# Altium schematic sheet Herba-Automata.SchDoc
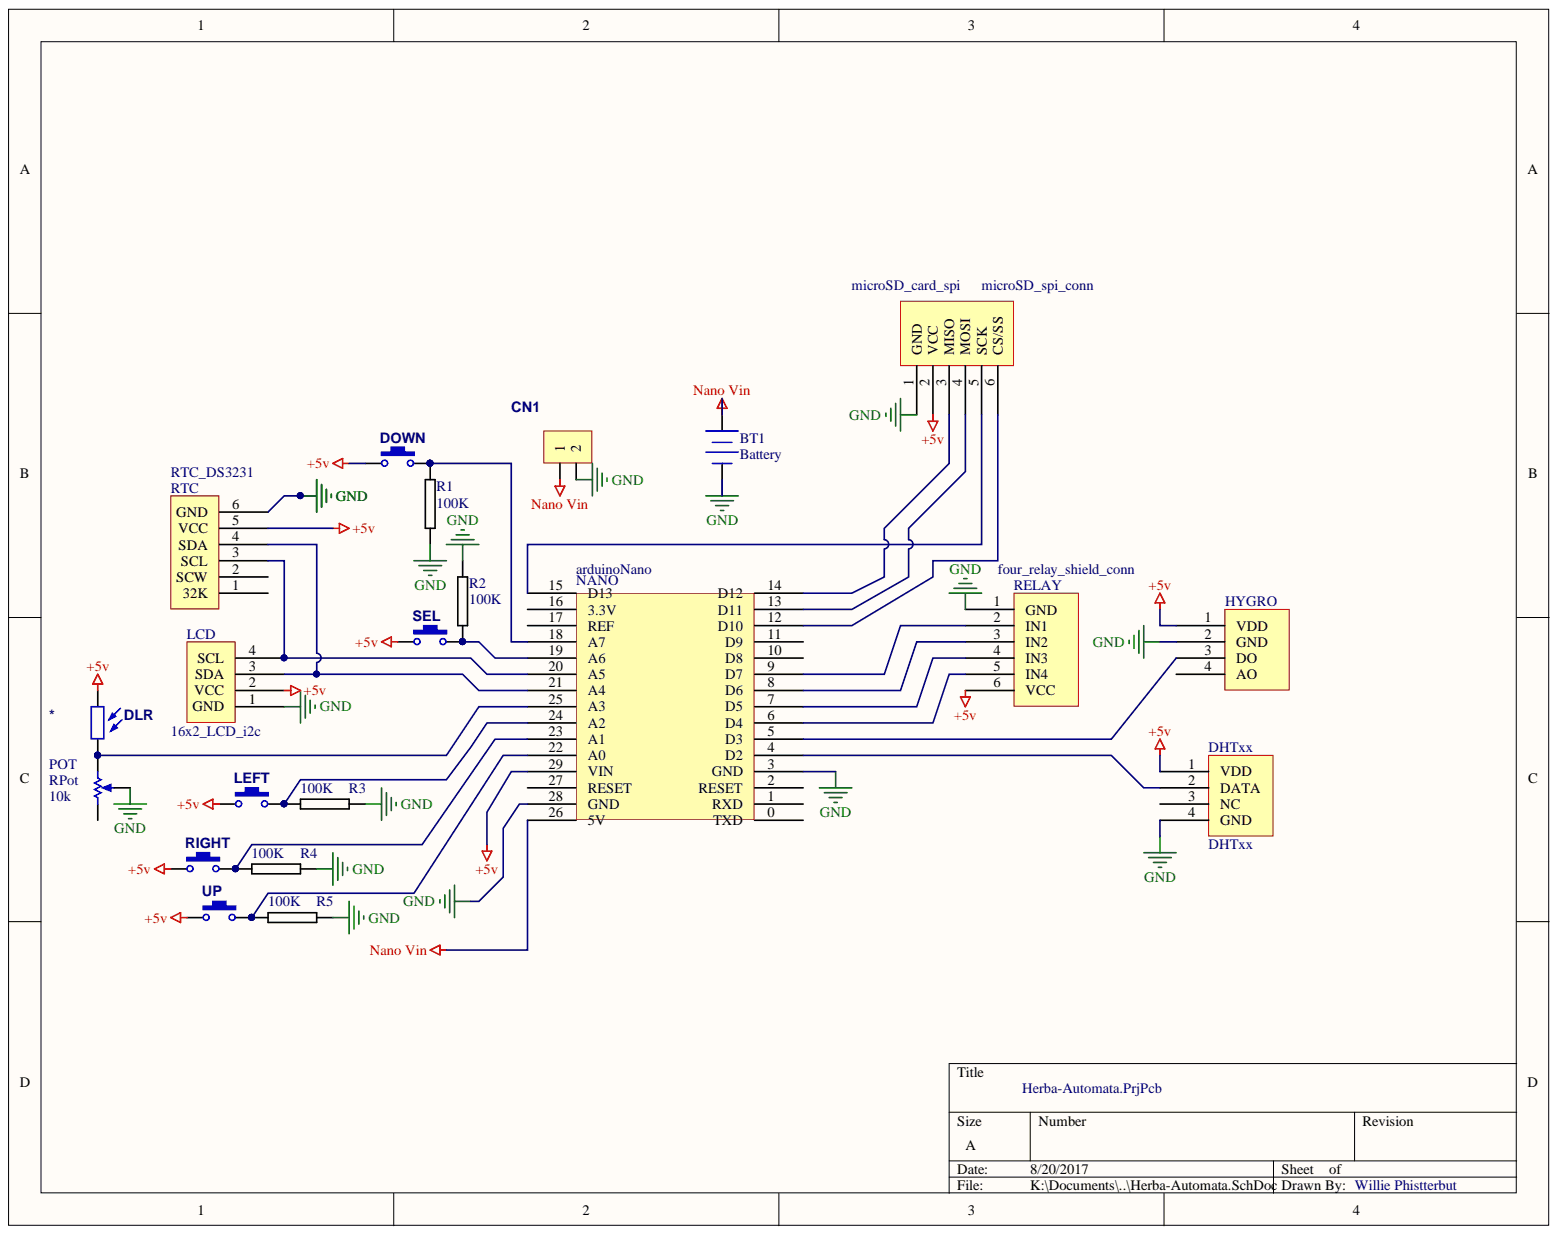
[diagram p0: Herba-Automata.SchDoc]

## Parts
BT1  Battery  [BAT-2]
CN1  CN_2x1  [GP_2x1_F]  (value not drawn)
DHTxx  DHTxx  [HDR1X4]
DLR  (no value)  [GM55]
DOWN  DTSM-65R  [DTSM-65R]  (value not drawn)
HYGRO  hygrometer  [GP_4x1_F]  (value not drawn)
LCD  16x2_LCD_i2c  [16x2_LCD_i2c]
LEFT  DTSM-65R  [DTSM-65R]  (value not drawn)
microSD_card_spi  microSD_spi_conn  [GP_6x1]
NANO  arduinoNano  [Arduino nano]
POT  RPot  value 10k  [VR4]
R1  100K  [0805R-100K]
R2  100K  [0805R-100K]
R3  100K  [0805R-100K]
R4  100K  [0805R-100K]
R5  100K  [0805R-100K]
RELAY  four_relay_shield_conn  [GP_6x1_F]
RIGHT  DTSM-65R  [DTSM-65R]  (value not drawn)
RTC  RTC_DS3231  [GP_6x1]
SEL  DTSM-65R  [DTSM-65R]  (value not drawn)
UP  DTSM-65R  [DTSM-65R]  (value not drawn)

## Nets
+5V: DHTxx.1(VDD) DLR.1 DOWN.1 HYGRO.1(VDD) LCD.2(VCC) LEFT.1 microSD_card_spi.2(VCC) NANO.29(VIN) RELAY.6(VCC) RIGHT.1 RTC.5(VCC) SEL.1 UP.1
GND: BT1.2 CN1.2 DHTxx.4(GND) HYGRO.2(GND) LCD.1(GND) microSD_card_spi.1(GND) NANO.3(GND) NANO.28(GND) POT.3 R1.2 R2.1 R3.1 R4.1 R5.1 RELAY.1(GND) RTC.6(GND)
NANO VIN: BT1.1 CN1.1 NANO.26(5V)
NetDHTxx_2: DHTxx.2(DATA) NANO.4(D2)
NetDLR_2: DLR.2 NANO.25(A3) POT.2
NetDOWN_2: DOWN.2 NANO.18(A7) R1.1
NetHYGRO_3: HYGRO.3(DO) NANO.5(D3)
NetLCD_3: LCD.3(SDA) NANO.21(A4) RTC.4(SDA)
NetLCD_4: LCD.4(SCL) NANO.20(A5) RTC.3(SCL)
NetLEFT_2: LEFT.2 NANO.24(A2) R3.2
NetmicroSD_card_spi_3: microSD_card_spi.3(MISO) NANO.14(D12)
NetmicroSD_card_spi_4: microSD_card_spi.4(MOSI) NANO.13(D11)
NetmicroSD_card_spi_5: microSD_card_spi.5(SCK) NANO.15(D13)
NetmicroSD_card_spi_6: microSD_card_spi.6(CS/SS) NANO.12(D10)
NetNANO_6: NANO.6(D4) RELAY.5(IN4)
NetNANO_7: NANO.7(D5) RELAY.4(IN3)
NetNANO_8: NANO.8(D6) RELAY.3(IN2)
NetNANO_9: NANO.9(D7) RELAY.2(IN1)
NetNANO_19: NANO.19(A6) R2.2 SEL.2
NetNANO_22: NANO.22(A0) R5.2 UP.2
NetNANO_23: NANO.23(A1) R4.2 RIGHT.2

Not connected: DHTxx 3(NC); HYGRO 4(AO); NANO 0(TXD) 1(RXD) 2(RESET) 10(D8) 11(D9) 16(3.3V) 17(REF) 27(RESET); POT 1; RTC 1(32K) 2(SCW)

## Library data of the parts
BT1 = Battery: Multicell Battery; Component Kind: Standard; LatestRevisionDate: 17-Jul-2002; LatestRevisionNote: Re-released for DXP Platform.; Library Name: Miscellaneous Devices.IntLib; PackageDescription: Battery; 2 Leads; PackageReference: BAT-2; Published: 24-Mar-1999; Publisher: Altium Limited
DHTxx = DHT11: Component Kind: Standard; Library Name: Herba-Automata.SCHLIB
DLR = GM55: PHOTOCELL 4K-11K OHM 4.20MM; Component Kind: Standard; Published: 29-Aug-2006; Library Name: photoelements.IntLib
DOWN LEFT RIGHT SEL UP = DTSM-65R: DIPTRONICS Red 6x6mm SMD Tactile SPST-NO Switch; Component Kind: Standard; Supplier 1: TME; Supplier Part Number 1: DTSM-65R-V-B; Library Name: microswitches.IntLib
POT = RPot: Set Position: 0.5; Sim Note: Enter the Resistance value and the set point into parameter fields.; Component Kind: Standard; LatestRevisionDate: 17-Jul-2002; LatestRevisionNote: Re-released for DXP Platform.; Library Name: Miscellaneous Devices.IntLib; PackageDescription: Variable Resistor, Thru-Hole; 3 Leads; PackageReference: VR5; Published: 8-Jun-2000; Simulation: POT; Publisher: Altium Limited
R1-R5 = 0805R-100K: RESISTOR 0805 1/4 WATT THIN FILM; Component Kind: Standard; Library Name: vh_altium_library.intLib; Supplier 1: Digi-Key; Supplier Part Number 1: RMCF0805FT100KTR-ND
CN1 = CN_2x1: Component Kind: Standard; Library Name: goldpin_female.IntLib
HYGRO = hygrometer: Component Kind: Standard; Library Name: Herba-Automata.SCHLIB
LCD = 16x2_LCD_i2c: Component Kind: Standard; Library Name: Herba-Automata.SCHLIB
microSD_card_spi = microSD_spi_conn: Component Kind: Standard; Library Name: Herba-Automata.SCHLIB
NANO = arduinoNano: Component Kind: Standard; Library Name: nanoArduino.SchLib
RELAY = four_relay_shield_conn: Component Kind: Standard; Library Name: Herba-Automata.SCHLIB
RTC = RTC_DS3231: Component Kind: Standard; Library Name: Herba-Automata.SCHLIB
